# Altium schematic sheet interface.SchDoc(interface)
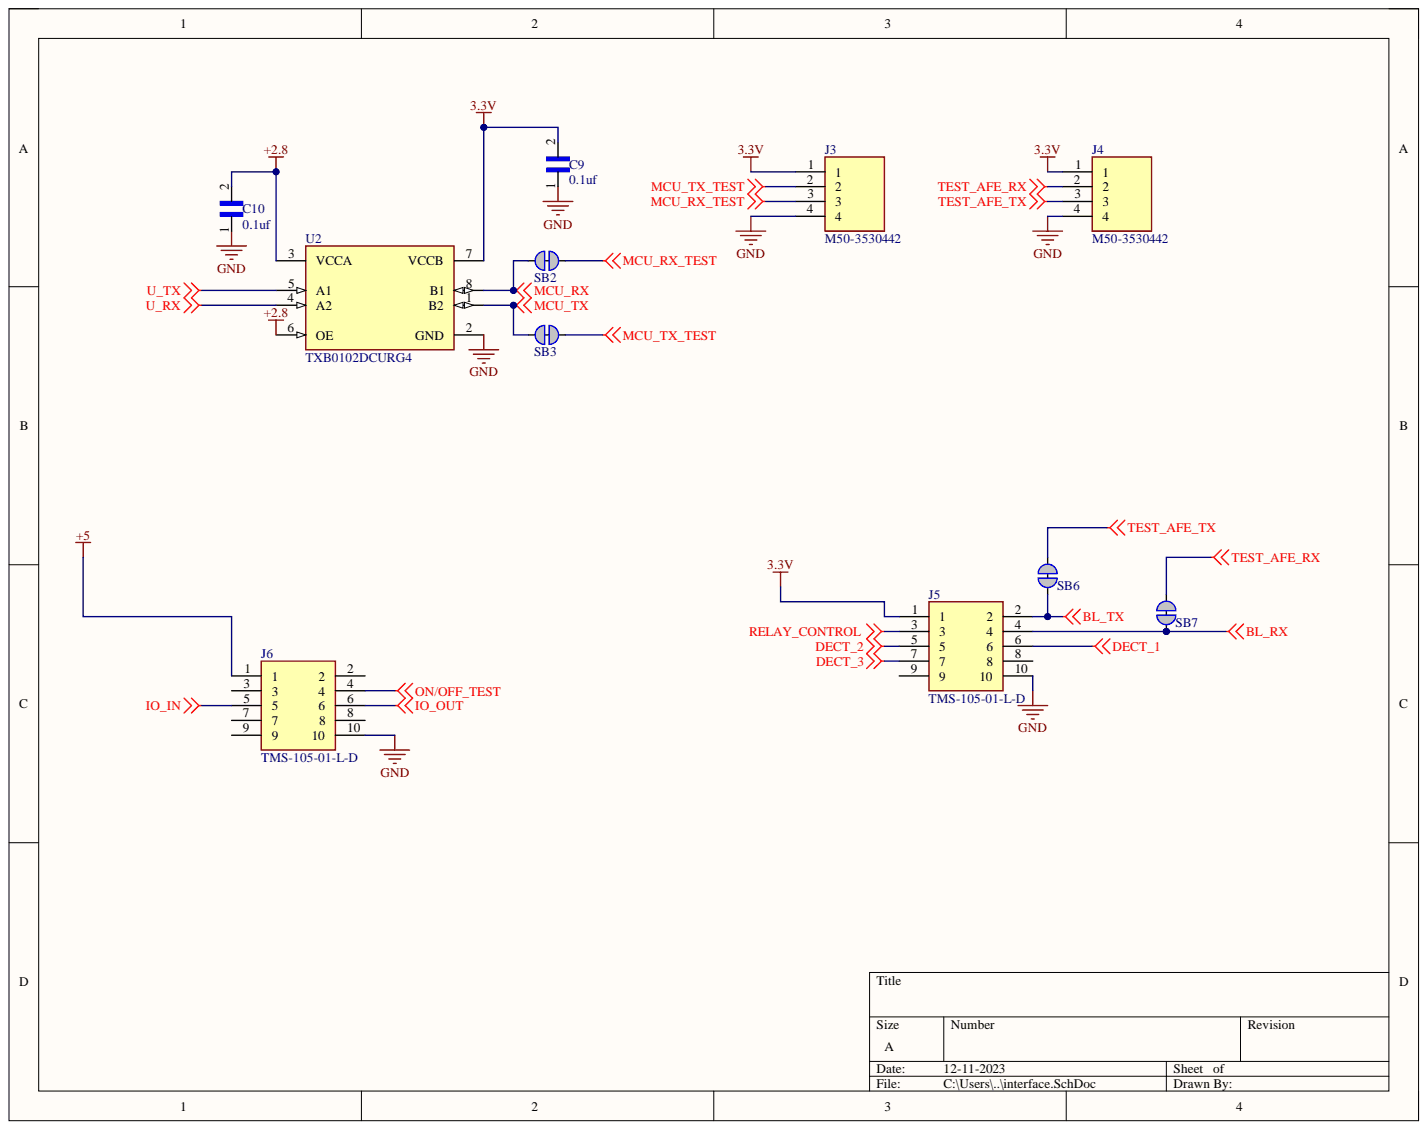
[diagram p0: interface.SchDoc]

## Parts
C9  value 0.1uf  [CAPC1608X90N]
C10  value 0.1uf  [CAPC1608X90N]
J3  M50-3530442  [HDRV4W40P0X127_1X4_508X210X420P]
J4  M50-3530442  [HDRV4W40P0X127_1X4_508X210X420P]
J5  TMS-105-01-L-D  [HDRV10W51P254X127_2X5_635X498X838P]
J6  TMS-105-01-L-D  [HDRV10W51P254X127_2X5_635X498X838P]
SB2  Solderbridge  [SOLDERBRIDGE_SHORTED]  (value not drawn)
SB3  Solderbridge  [SOLDERBRIDGE_SHORTED]  (value not drawn)
SB6  Solderbridge  [SOLDERBRIDGE_SHORTED]  (value not drawn)
SB7  Solderbridge  [SOLDERBRIDGE_SHORTED]  (value not drawn)
U2  TXB0102DCURG4  [SOP50P310X90-8N]

## Nets
3.3V: C9.2 J3.1 J4.1 J5.1 U2.7(VCCB)
+2.8: C10.2 U2.3(VCCA) U2.6(OE)
+5: J6.1
BL_RX: J5.4 SB7.2
BL_TX: J5.2 SB6.1
DECT_1: J5.6
DECT_2: J5.5
DECT_3: J5.7
GND: C9.1 C10.1 J3.4 J4.4 J5.10 J6.10 U2.2(GND)
IO_IN: J6.5
IO_OUT: J6.6
MCU_RX: SB2.2 U2.8(B1)
MCU_RX_TEST: J3.3 SB2.1
MCU_TX: SB3.2 U2.1(B2)
MCU_TX_TEST: J3.2 SB3.1
ON/OFF_TEST: J6.4
RELAY_CONTROL: J5.3
TEST_AFE_RX: J4.2 SB7.1
TEST_AFE_TX: J4.3 SB6.2
U_RX: U2.4(A2)
U_TX: U2.5(A1)

Not connected: J5 8 9; J6 2 3 7 8 9

## Library data of the parts
C9 C10 = 06033G104ZAT4A: Cap Ceramic 0.1uF 25V Y5V -20% to 80% SMD 0603 85C T/R; MF: {'manufacturer': u'AVX'}; Availability: Unavailable; Component Kind: Standard; MP: 06033G104ZAT4A; Package: None; Price: None; Library Name: chargebot_alpha.IntLib
J3 J4 = M50-3530442: Connector; Manufacturer_Name: Harwin; Component Kind: Standard; Datasheet Link: https://cdn.harwin.com/pdfs/M50-353.pdf; Height: 4.2mm; Manufacturer_Part_Number: M50-3530442; Mouser Part Number: 855-M50-3530442; Mouser Price/Stock: https://www.mouser.co.uk/ProductDetail/Harwin/M50-3530442?qs=%252BdQmOuGyFcH%2FaHqroeU8Uw%3D%3D
J5 J6 = TMS-105-01-L-D: Connector; Manufacturer_Name: SAMTEC; Arrow Part Number: TMS-105-01-L-D; Arrow Price/Stock: https://www.arrow.com/en/products/tms-105-01-l-d/samtec; Component Kind: Standard; Datasheet Link: http://suddendocs.samtec.com/catalog_english/tms_th.pdf; Height: 8.38mm; Manufacturer_Part_Number: TMS-105-01-L-D; Mouser Part Number: 200-TMS10501LD; Mouser Price/Stock: https://www.mouser.com/Search/Refine.aspx?Keyword=200-TMS10501LD
SB2 SB3 SB6 SB7 = SOLDERBRIDGE_SHORTED: Shorted Solderbridge; Part Number: Solderbridge Shorted; Artikkelnr Noca: N.A.; Artikkelnr Simpro: N.A.; Component Kind: Net Tie; Footprint Doc: Solderbridge_Shorted; LatestRevisionDate: 2012-08-07; Pin Count: 2; Published: 2012-08-07; Value: Solderbridge; Library Name: apollo2-amaph1kk-kbr-brd.IntLib; Publisher: Nordic Semiconductor
U2 = e5aacda1e23edf748dee3cec588ab45: IC TRNSLTR BIDIRECTIONAL US8; Data Rate: 100Mbps; High Level Output Current: -20uA; Logic Function: Translator; Low Level Output Current: 20uA; Max Supply Voltage: 5.5V; Min Supply Voltage: 1.2V; Number of Bits: 2; Output Type: 3-STATE; Propagation Delay: 31ns; Turn-On Delay Time: 7.4ns; Case/Package: VSSOP; Component Kind: Standard; Lead Free: Contains Lead; Max Operating Temperature: 85°C; Min Operating Temperature: -40°C; Mount: Surface Mount; Number of Channels: 2; Number of Pins: 8; Package Quantity: 3000; Packaging: Tape and Reel; Radiation Hardening: No; RoHS Compliant: Yes; Series: -; Weight: 0.000339oz; Library Name: chargebot_alpha.IntLib
